# Altium schematic sheet TRINKIEROCKS P2.SchDoc
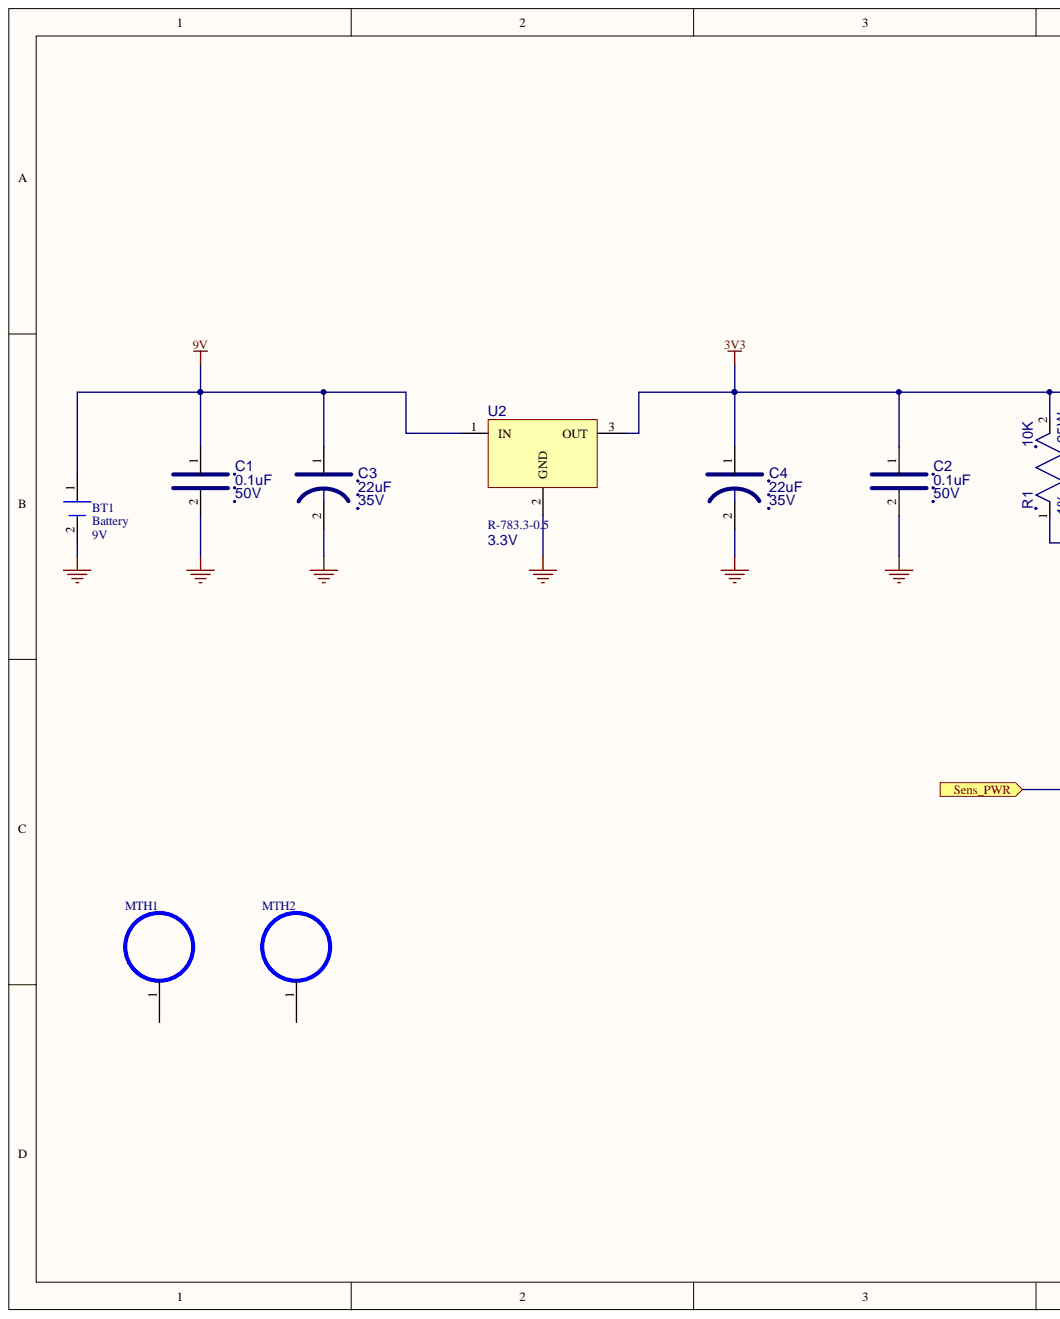
[diagram p0: TRINKIEROCKS P2.SchDoc, left]
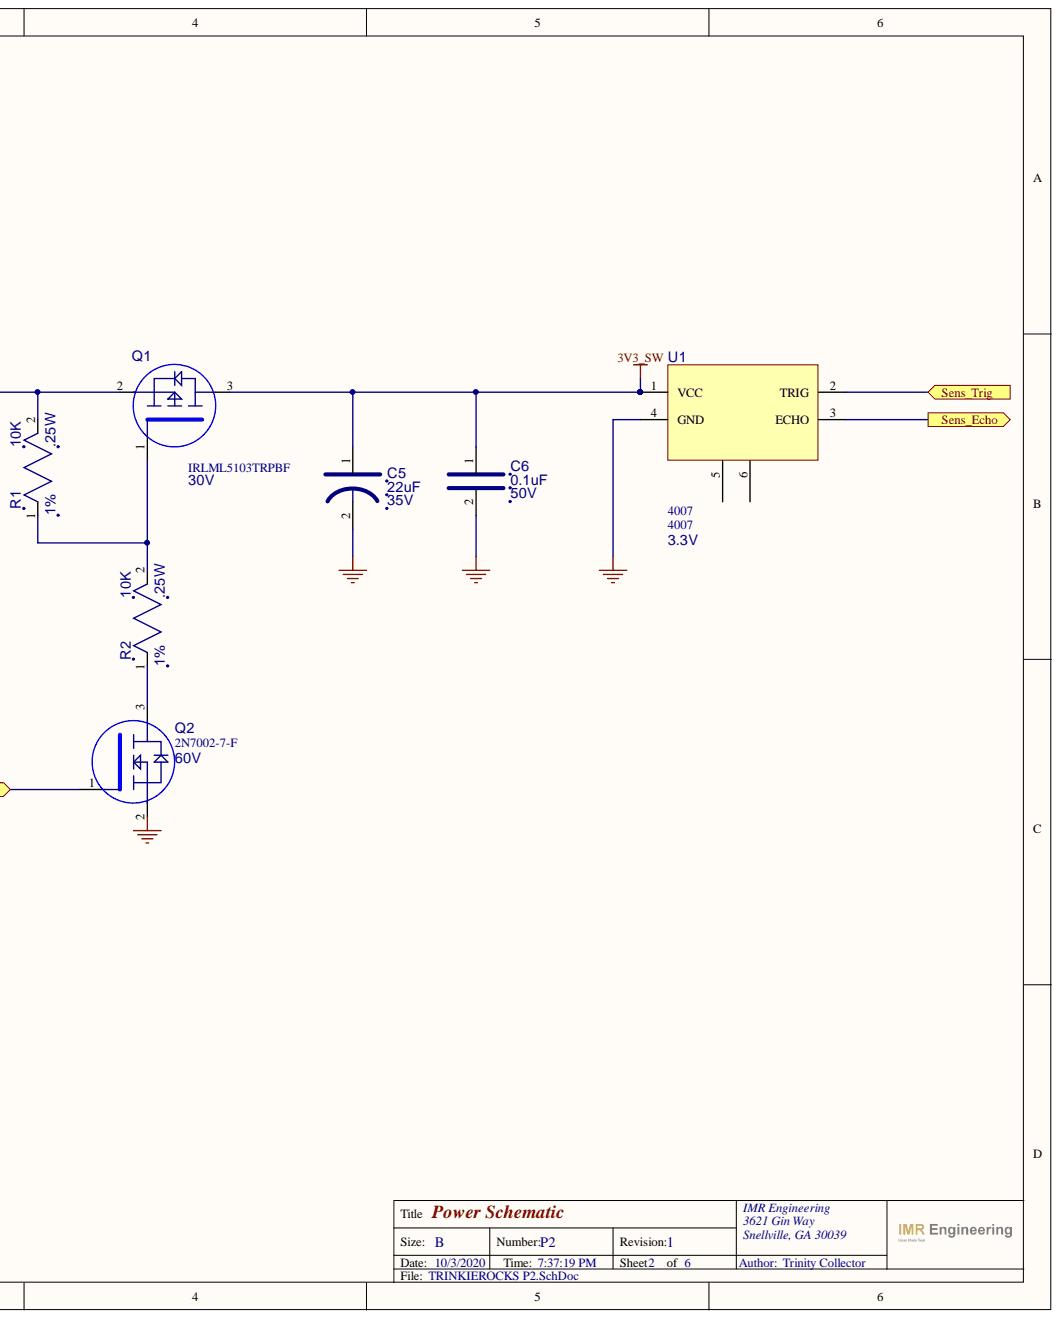
[diagram p1: TRINKIEROCKS P2.SchDoc, right]

## Parts
BT1  Battery  value 9V  [HDR_1X2_200MIL]
C1  value 0.1uF  VOLTAGE: 50V  [CAP_0805]  (hidden comment: 08055C104KAT2A)
C2  value 0.1uF  VOLTAGE: 50V  [CAP_0805]  (hidden comment: 08055C104KAT2A)
C3  value 22uF  VOLTAGE: 35V  [CAP_ALE_NF]  (hidden comment: CAP_22UF_35V_ALE)
C4  value 22uF  VOLTAGE: 35V  [CAP_ALE_NF]  (hidden comment: CAP_22UF_35V_ALE)
C5  value 22uF  VOLTAGE: 35V  [CAP_ALE_NF]  (hidden comment: CAP_22UF_35V_ALE)
C6  value 0.1uF  VOLTAGE: 50V  [CAP_0805]  (hidden comment: 08055C104KAT2A)
MTH1  MOUNT_HOLE_5MM  [MT_HOLE_5MM]  (value not drawn)  (no BOM)
MTH2  MOUNT_HOLE_5MM  [MT_HOLE_5MM]  (value not drawn)  (no BOM)
Q1  IRLML5103TRPBF  value 30V  [SOT23 - TRANSISTOR]
Q2  2N7002-7-F  value 60V  [SOT23 - TRANSISTOR]
R1  value 10K  POWER: .25W  TOLERANCE: 1%  [RES_0805]  (hidden comment: SG73S2ATTD1002F)
R2  value 10K  POWER: .25W  TOLERANCE: 1%  [RES_0805]  (hidden comment: SG73S2ATTD1002F)
U1  4007  value 3.3V  [SENS]
U2  R-783.3-0.5  value 3.3V  [RECOM_R-78E]

## Ports
SENS_ECHO, SENS_PWR, SENS_TRIG

## Nets
3V3: C2.1 C4.1 Q1.2 R1.2 U2.3
3V3_SW: C5.1 C6.1 Q1.3 U1.1(VCC)
9V: BT1.1 C1.1 C3.1 U2.1
GND: BT1.2 C1.2 C2.2 C3.2 C4.2 C5.2 C6.2 Q2.2 U1.4(GND) U2.2
NetQ1_1: Q1.1 R1.1 R2.2
NetQ2_1: Q2.1 ; port SENS_PWR
NetQ2_3: Q2.3 R2.1
NetU1_2: U1.2(TRIG) ; port SENS_TRIG
NetU1_3: U1.3(ECHO) ; port SENS_ECHO

Not connected: MTH1 1; MTH2 1; U1 5 6

## Library data of the parts
BT1 = 9V-BAT-HOLD: DC DC CONVERTER 3.3V 2W; MFG: Eagle Plastic Devices; Case/Package: Custom 9V Holder; Component Kind: Standard; Supplier 1: Eagle Plastic Devices; Supplier Part Number 1: 12BH611-GR; Library Name: Trinkie.SchLib
C1 C2 C6 = CAP_0.1UF_50V_CER: CAP CER 0.1UF 50V X7R 0805; MFG: AVX; TOLERANCE: 10%; Case/Package: 0805; Component Kind: Standard; MFG_PN: 08055C104KAT2A; Supplier 1: Digi-Key; Supplier Part Number 1: 478-1395-1-ND; Library Name: Trinkie.SchLib
C3-C5 = CAP_22UF_35V_ALE: CAP, AL E, 22UF, 35V, 20%, ROHS; MFG: NICHICON; MFG_PN: UWX1V220MCL1GB; Component Kind: Standard; Supplier 1: Digi-Key; Supplier Part Number 1: 493-2118-1-ND; TOLERANCE: 20%; Library Name: Trinkie.SchLib
MTH1 MTH2 = MOUNT_HOLE_5MM: 5MM IN D. MT HOLE; Library Name: Trinkie.SchLib
Q1 = MOSFET_PCH_IRLML5103TRPBF: MOSFET P-CH 30V 760MA SOT-23; MFG: Infineon Technologies; Case/Package: SOT-23; Component Kind: Standard; MFG_PN: IRLML5103TRPBF; Supplier 1: Digi-Key; Supplier Part Number 1: IRLML5103PBFCT-ND; Library Name: Trinkie.SchLib
Q2 = MOSFET_NCH_2N7002_115MA: MOSFET N CHN, VDS=60V, 115 mA, SOT 23; MFG: DIODES INC; Case/Package: SOT23; Component Kind: Standard; MFG_PN: 2N7002-7-F; Supplier 1: Digi-Key; Supplier Part Number 1: 2N7002-FDICT-ND; Library Name: Trinkie.SchLib
R1 R2 = RES_10K_0805_1/4W_1%: RES SMD 10K OHM 1% 1/4W 0805; MFG: KOA Speer Electronics, Inc.; Case/Package: 0805; Component Kind: Standard; MFG_PN: SG73S2ATTD1002F; Supplier 1: Digi-Key; Supplier Part Number 1: 2019-SG73S2ATTD1002FCT-ND; Library Name: Trinkie.SchLib
U1 = SENSOR_ULTRASONIC_3.3V: ULTRASONIC SENSOR DISTANCE 3V; MFG: Adafruit Industries LLC; Component Kind: Standard; MFG_PN: 4007; Supplier 1: Digi-Key; Supplier Part Number 1: 1528-2832-ND; Library Name: Trinkie.SchLib
U2 = IC_REG_3.3V: DC DC CONVERTER 3.3V 2W; MFG: Recom Power; Case/Package: Tube; Component Kind: Standard; MFG_PN: R-783.3-0.5; Supplier 1: Digi-Key; Supplier Part Number 1: 945-1035-ND; Library Name: Trinkie.SchLib
